# Altium schematic sheet karmanDB_gps&gs.SchDoc
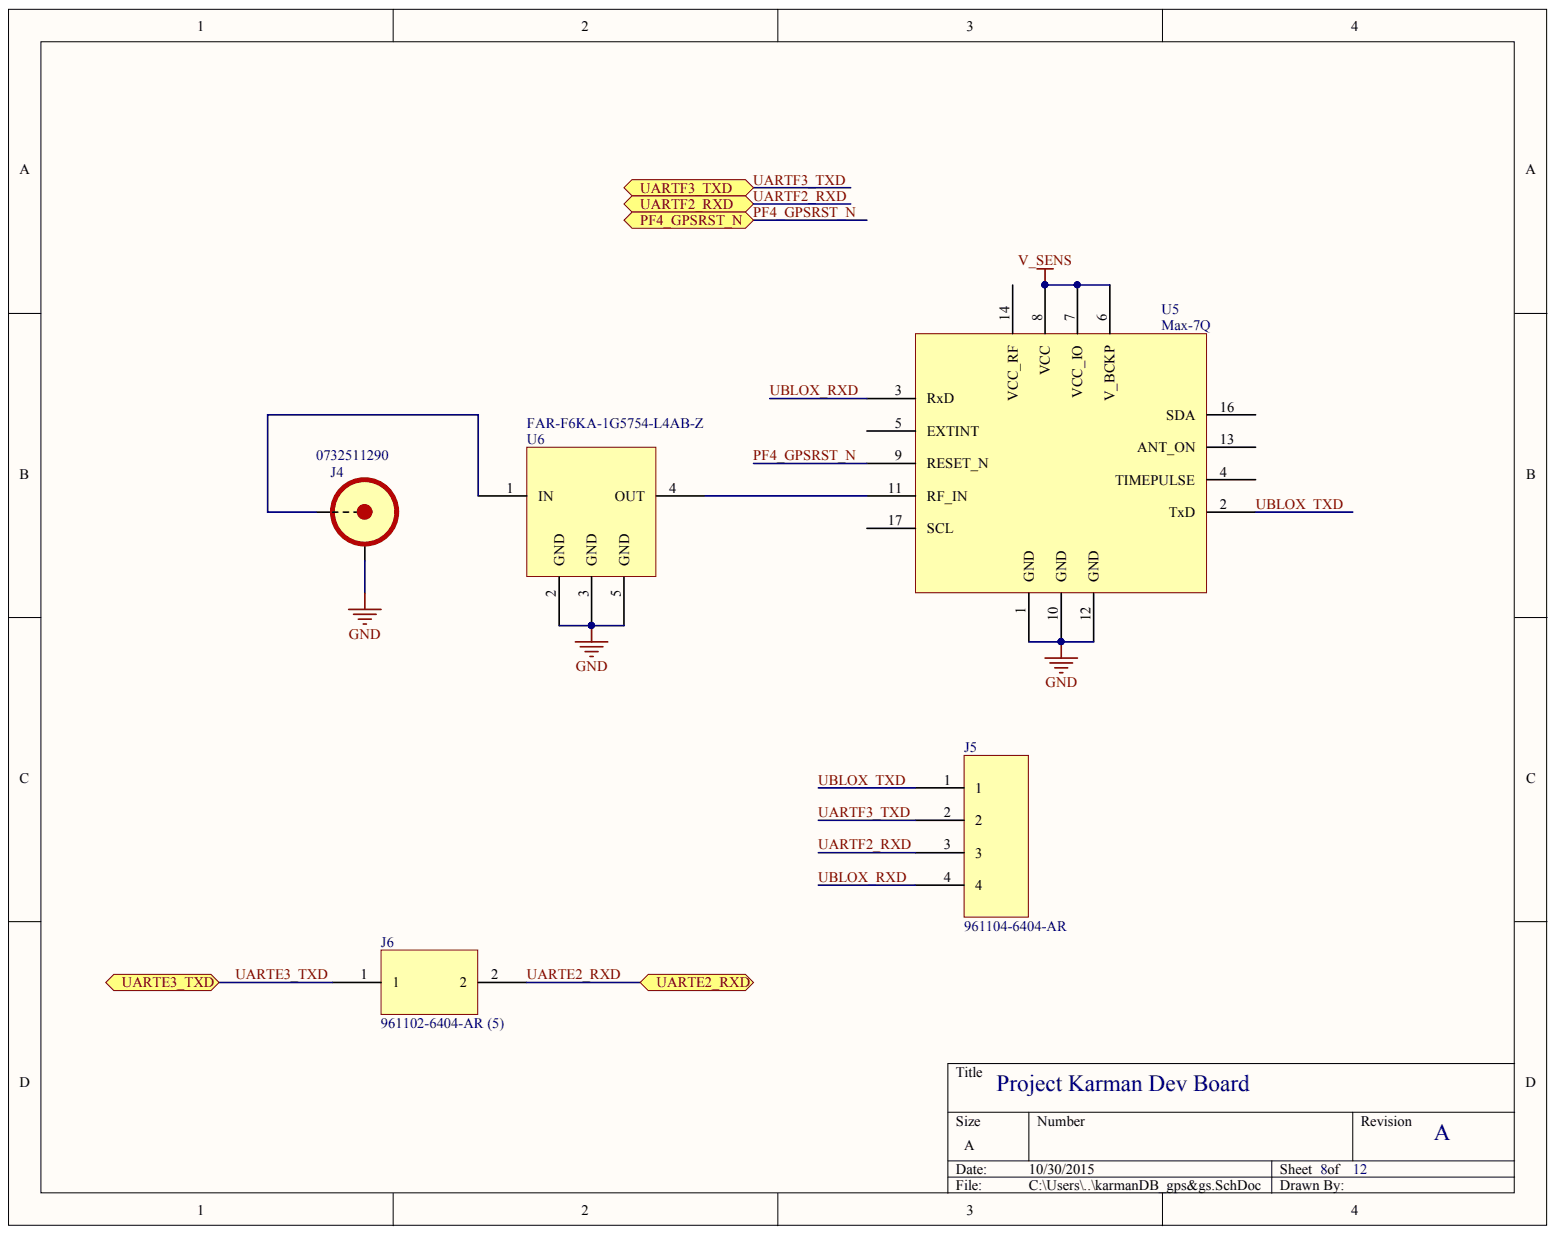
[diagram p0: karmanDB_gps&gs.SchDoc]

## Parts
J4  0732511290
J5  961104-6404-AR
J6  961102-6404-AR (5)  Manufacturer Part Number: 961102-6404-AR
U5  Max-7Q
U6  FAR-F6KA-1G5754-L4AB-Z

## Ports
PF4_GPSRST_N, UARTE2_RXD, UARTE3_TXD, UARTF2_RXD, UARTF3_TXD

## Nets
GND: J4.SHIELD U5.1(GND) U5.10(GND) U5.12(GND) U6.2(GND) U6.3(GND) U6.5(GND)
V_SENS: U5.6(V_BCKP) U5.7(VCC_IO) U5.8(VCC)
PF4_GPSRST_N: U5.9(RESET_N) ; port PF4_GPSRST_N
UARTE2_RXD: J6.2 ; port UARTE2_RXD
UARTE3_TXD: J6.1 ; port UARTE3_TXD
UARTF2_RXD: J5.3 ; port UARTF2_RXD
UARTF3_TXD: J5.2 ; port UARTF3_TXD
UBLOX_RXD: J5.4 U5.3(RxD)
UBLOX_TXD: J5.1 U5.2(TxD)
NetJ4_PIN: J4.PIN U6.1(IN)
NetU5_11: U5.11(RF_IN) U6.4(OUT)

Not connected: U5 4(TIMEPULSE) 5(EXTINT) 13(ANT_ON) 14(VCC_RF) 16(SDA) 17(SCL)

## Library data of the parts
J4 = 0732511290: CONN SMA JACK EDGE MNT 50 OHM; Manufacturer: Molex, LLC; Body Finish: Gold; Body Material: Brass; Center Contact Material: Beryllium Copper; Connector Type: Jack, Female Socket; Contact Termination: Solder; Dielectric Material: Polytetrafluoroethylene (PTFE); Frequency - Max: 18GHz; Impedance: 50 Ohm; Voltage Rating: 500V; Cable Group: -; Category: Connectors, Interconnects; Center Contact Plating: Gold; Component Kind: Standard; ComponentLink1Description: http://www.molex.com/pdm_docs/sd/732511290_sd.pdf; ComponentLink1URL: http://www.molex.com/pdm_docs/sd/732511290_sd.pdf; Connector Style: SMA; Fastening Type: Threaded; Features: -; Housing Color: Gold; Ingress Protection: -; Manufacturer Part Number: 0732511290; Mounting Type: Board Edge, End Launch; Panel Mount, Bulkhead; Operating Temperature: -65°C ~ 165°C; Packaging: Tray; Pricing 1: 1=6.6, 10=6.327, 25=5.7772, 50=5.502, 100=5.2269, 250=4.67672, 500=4.4016, 1000=3.8514, 2500=3.65883 (USD); RoHS: RoHS Compliant; Shield Termination: Solder; Stock 1: 1325; Supplier 1: Digi-Key; Supplier Part Number 1: WM9461-ND; Library Name: karman_pcb.SCHLIB
J5 = 961104-6404-AR: CONN HEADER VERT SGL 4POS GOLD; Manufacturer: 3M; Connector Type: Header, Unshrouded; Number of Positions: 4; Number of Positions Loaded: All; Pitch: 0.100" (2.54mm); Category: Connectors, Interconnects; Color: Black; Component Kind: Standard; ComponentLink1Description: http://multimedia.3m.com/mws/mediawebserver?66666UuZjcFSLXTtNxTElX&VEVuQEcuZgVs6EVs6E666666--; ComponentLink1URL: http://multimedia.3m.com/mws/mediawebserver?66666UuZjcFSLXTtNxTElX&VEVuQEcuZgVs6EVs6E666666--; Contact Finish: Gold; Contact Finish Thickness: Flash; Contact Mating Length: 0.217" (5.50mm); Contact Type: Male Pin; Fastening Type: -; Features: -; Manufacturer Part Number: 961104-6404-AR; Mounting Type: Through Hole; Number of Rows: 1; Packaging: Bulk; Pricing 1: 1=0.33, 10=0.315, 25=0.27, 50=0.2296, 100=0.2205, 250=0.198, 500=0.189, 1000=0.1575, 2500=0.144 (USD); RoHS: RoHS Compliant; Row Spacing: -; Stock 1: 17691; Supplier 1: Digi-Key; Supplier Part Number 1: 3M9449-ND; Termination: Solder; Library Name: karman_pcb.SCHLIB
J6 = 961102-6404-AR (5): CONN HEADER VERT SGL 2POS GOLD; Manufacturer: 3M; Connector Type: Header, Unshrouded; Number of Positions: 2; Number of Positions Loaded: All; Pitch: 0.100" (2.54mm); Category: Connectors, Interconnects; Color: Black; Component Kind: Standard; ComponentLink1Description: http://multimedia.3m.com/mws/mediawebserver?66666UuZjcFSLXTtNxTElX&VEVuQEcuZgVs6EVs6E666666--; ComponentLink1URL: http://multimedia.3m.com/mws/mediawebserver?66666UuZjcFSLXTtNxTElX&VEVuQEcuZgVs6EVs6E666666--; Contact Finish: Gold; Contact Finish Thickness: Flash; Contact Mating Length: 0.217" (5.50mm); Contact Type: Male Pin; Fastening Type: -; Features: -; Mounting Type: Through Hole; Number of Rows: 1; Packaging: Bulk; Pricing 1: 1=0.16, 10=0.15, 25=0.128, 50=0.112, 100=0.104, 250=0.092, 500=0.088, 1000=0.072, 2500=0.066 (USD); RoHS: RoHS Compliant; Row Spacing: -; Stock 1: 60000; Supplier 1: Digi-Key; Supplier Part Number 1: 3M9447-ND; Termination: Solder; Library Name: karman_pcb.SCHLIB
U5 = Max-7Q: MAX-7Q ublox GPS chip; Component Kind: Standard; Library Name: karman_pcb.SCHLIB
U6 = FAR-F6KA-1G5754-L4AB-Z: FILTER SAW 1.5754GHZ GPS SMD; Manufacturer: Taiyo Yuden; Bandwidth: 2MHz; Filter Type: Band Pass; Frequency: 1.575GHz Center; Insertion Loss: 0.44dB; Applications: GPS; Category: Filters; Component Kind: Standard; ComponentLink1Description: http://www.yuden.co.jp/productdata/sheet/L4AB.pdf; ComponentLink1URL: http://www.yuden.co.jp/productdata/sheet/L4AB.pdf; Height (Max): 0.020" (0.50mm); Manufacturer Part Number: FAR-F6KA-1G5754-L4AB-Z; Mounting Type: Surface Mount; Package / Case: 5-SMD, No Lead; Packaging: Cut Tape (CT); Pricing 1: 1=0.54, 10=0.512, 25=0.4952, 50=0.4042, 100=0.363, 250=0.33, 500=0.3135, 1000=0.2805 (USD); RoHS: RoHS Compliant; Size / Dimension: 0.055" L x 0.039" W (1.40mm x 1.00mm); Stock 1: 8970; Supplier 1: Digi-Key; Supplier Part Number 1: 587-2995-1-ND; Library Name: karman_pcb.SCHLIB
